# Altium schematic sheet Peripheral.SchDoc
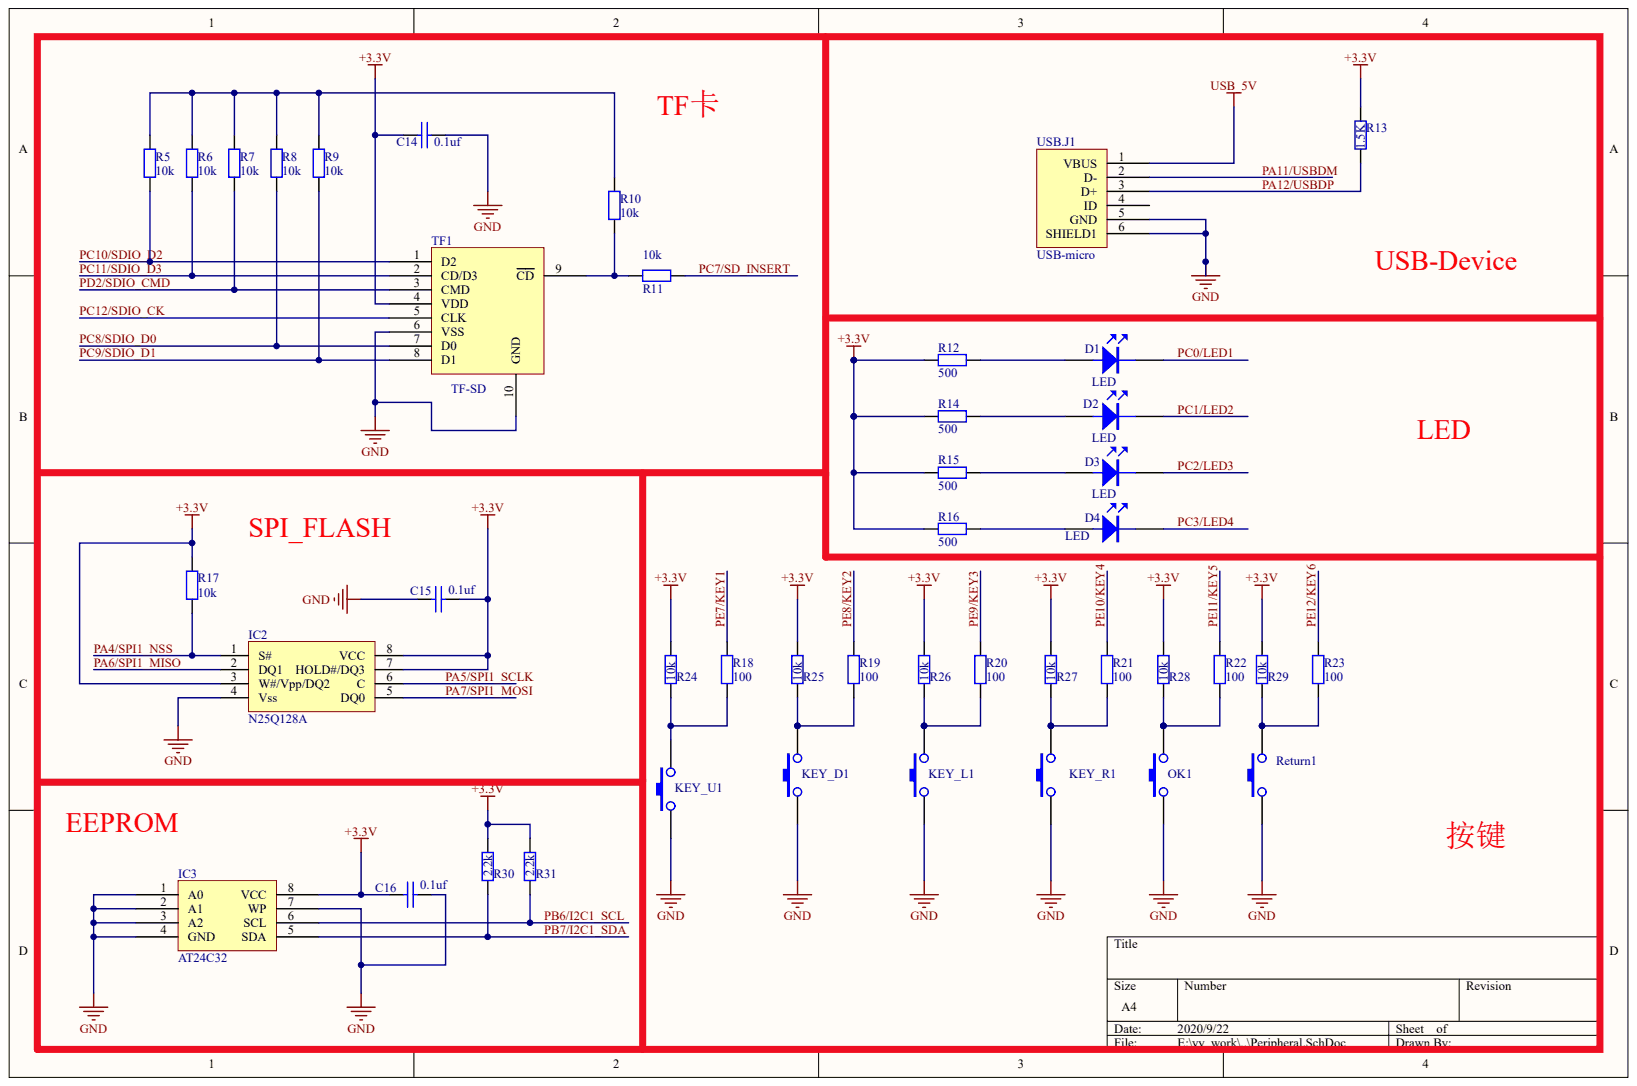
[diagram p0: Peripheral.SchDoc]

## Parts
C14  0.1uf
C15  0.1uf
C16  0.1uf
D1  LED
D2  LED
D3  LED
D4  LED
IC2  N25Q128A
IC3  AT24C32
KEY_D1  SW-PB  (value not drawn)
KEY_L1  SW-PB  (value not drawn)
KEY_R1  SW-PB  (value not drawn)
KEY_U1  SW-PB  (value not drawn)
OK1  SW-PB  (value not drawn)
R5  10k
R6  10k
R7  10k
R8  10k
R9  10k
R10  10k
R11  10k
R12  500
R13  1.5K
R14  500
R15  500
R16  500
R17  10k
R18  100
R19  100
R20  100
R21  100
R22  100
R23  100
R24  10k
R25  10k
R26  10k
R27  10k
R28  10k
R29  10k
R30  2.2k
R31  2.2k
Return1  SW-PB  (value not drawn)
TF1  TF-SD
USB.J1  USB-micro

## Nets
+3.3V: C14.1 C15.2 C16.1 IC2.3(W#/Vpp/DQ2) IC2.7(HOLD#/DQ3) IC2.8(VCC) IC3.8(VCC) R12.2 R13.2 R14.2 R15.2 R16.2 R17.2 R24.2 R25.2 R26.2 R27.2 R28.2 R29.2 R30.2 R31.2 TF1.4(VDD)
GND: C14.2 C15.1 C16.2 IC2.4(Vss) IC3.1(A0) IC3.2(A1) IC3.3(A2) IC3.4(GND) IC3.7(WP) KEY_D1.1 KEY_L1.1 KEY_R1.1 KEY_U1.1 OK1.1 Return1.1 TF1.6(VSS) TF1.10(GND) USB.J1.5(GND) USB.J1.6(SHIELD1)
USB_5V: USB.J1.1(VBUS)
PA4/SPI1_NSS: IC2.1(S#) R17.1
PA5/SPI1_SCLK: IC2.6(C)
PA6/SPI1_MISO: IC2.2(DQ1)
PA7/SPI1_MOSI: IC2.5(DQ0)
PA11/USBDM: USB.J1.2(D-)
PA12/USBDP: R13.1 USB.J1.3(D+)
PB6/I2C1_SCL: IC3.6(SCL) R31.1
PB7/I2C1_SDA: IC3.5(SDA) R30.1
PC0/LED1: D1.2
PC1/LED2: D2.2
PC2/LED3: D3.2
PC3/LED4: D4.2
PC7/SD_INSERT: R11.2
PC8/SDIO_D0: R8.1 TF1.7(D0)
PC9/SDIO_D1: R9.1 TF1.8(D1)
PC10/SDIO_D2: R5.1 TF1.1(D2)
PC11/SDIO_D3: R6.1 TF1.2(CD/D3)
PC12/SDIO_CK: TF1.5(CLK)
PD2/SDIO_CMD: R7.1 TF1.3(CMD)
PE7/KEY1: R18.2
PE8/KEY2: R19.2
PE9/KEY3: R20.2
PE10/KEY4: R21.2
PE11/KEY5: R22.2
PE12/KEY6: R23.2
NetD1_1: D1.1 R12.1
NetD2_1: D2.1 R14.1
NetD3_1: D3.1 R15.1
NetD4_1: D4.1 R16.1
NetKEY_D1_2: KEY_D1.2 R19.1 R25.1
NetKEY_L1_2: KEY_L1.2 R20.1 R26.1
NetKEY_R1_2: KEY_R1.2 R21.1 R27.1
NetKEY_U1_2: KEY_U1.2 R18.1 R24.1
NetOK1_2: OK1.2 R22.1 R28.1
NetR5_2: R5.2 R6.2 R7.2 R8.2 R9.2 R10.2
NetR10_1: R10.1 R11.1 TF1.9(CD)
NetR23_1: R23.1 R29.1 Return1.2

Not connected: USB.J1 4(ID)

## Library data of the parts
C14-C16 D1-D4 IC2 IC3 KEY_D1 KEY_L1 KEY_R1 KEY_U1 OK1 R5-R31 Return1 TF1 USB.J1 = (no symbol name)
  C14: Value: 0.1uf
  C15 C16: value: 0.1uf
  R5-R11 R17 R24-R29: value: 10k
  R12 R14-R16: value: 500
  R13: value: 1.5K
  R18-R23: value: 100
  R30 R31: value: 2.2k
